# Altium schematic sheet 5_REG2.SchDoc
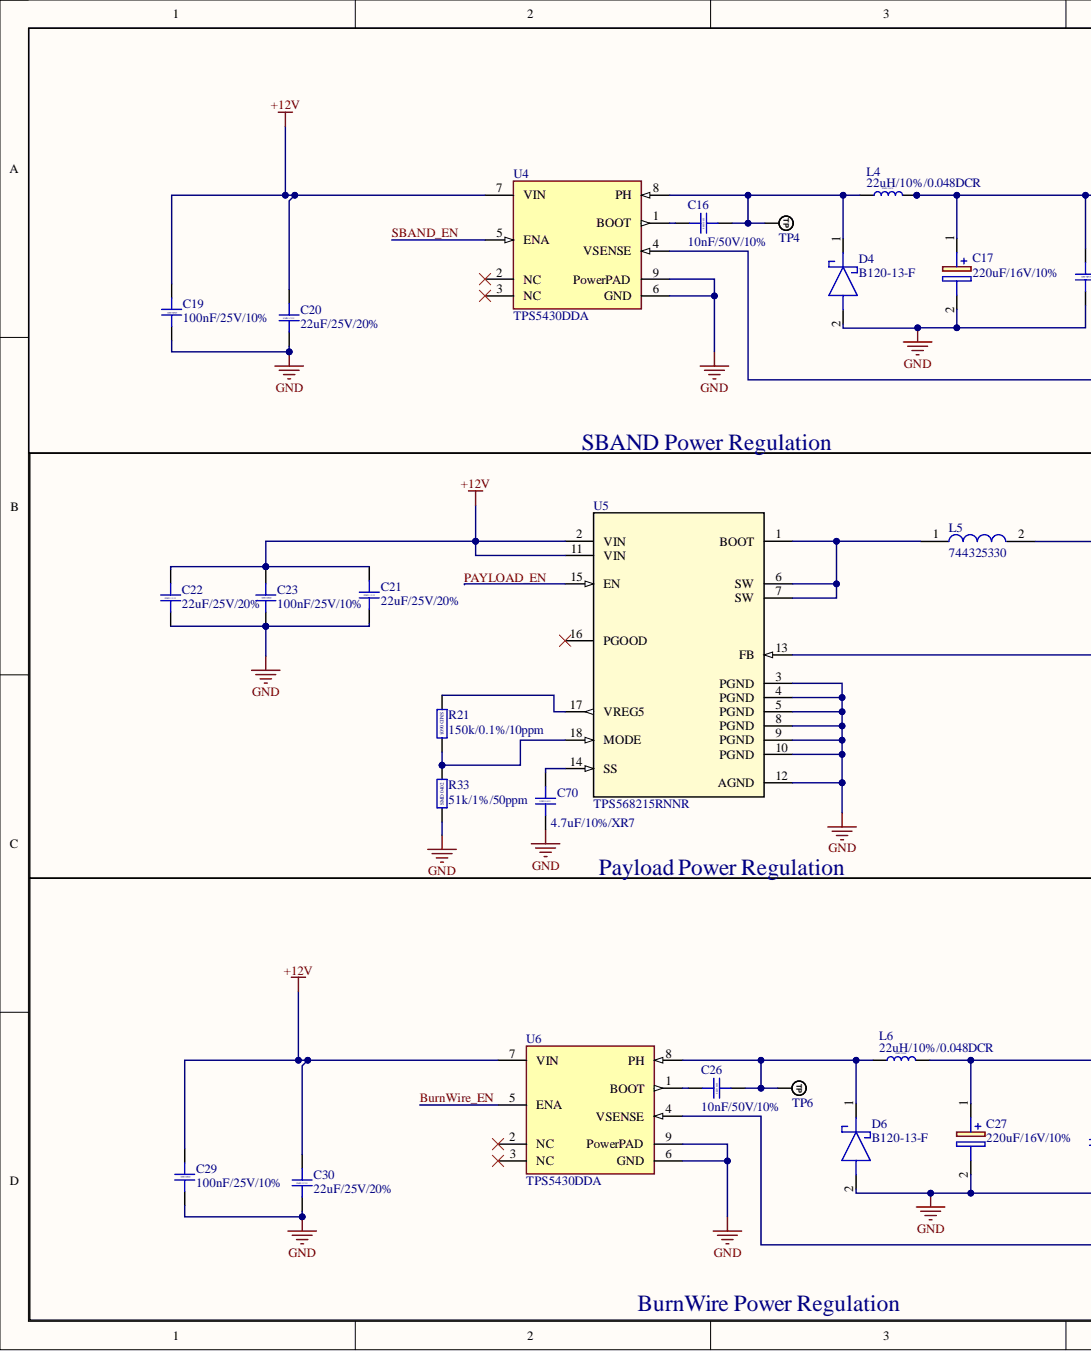
[diagram p0: 5_REG2.SchDoc, left]
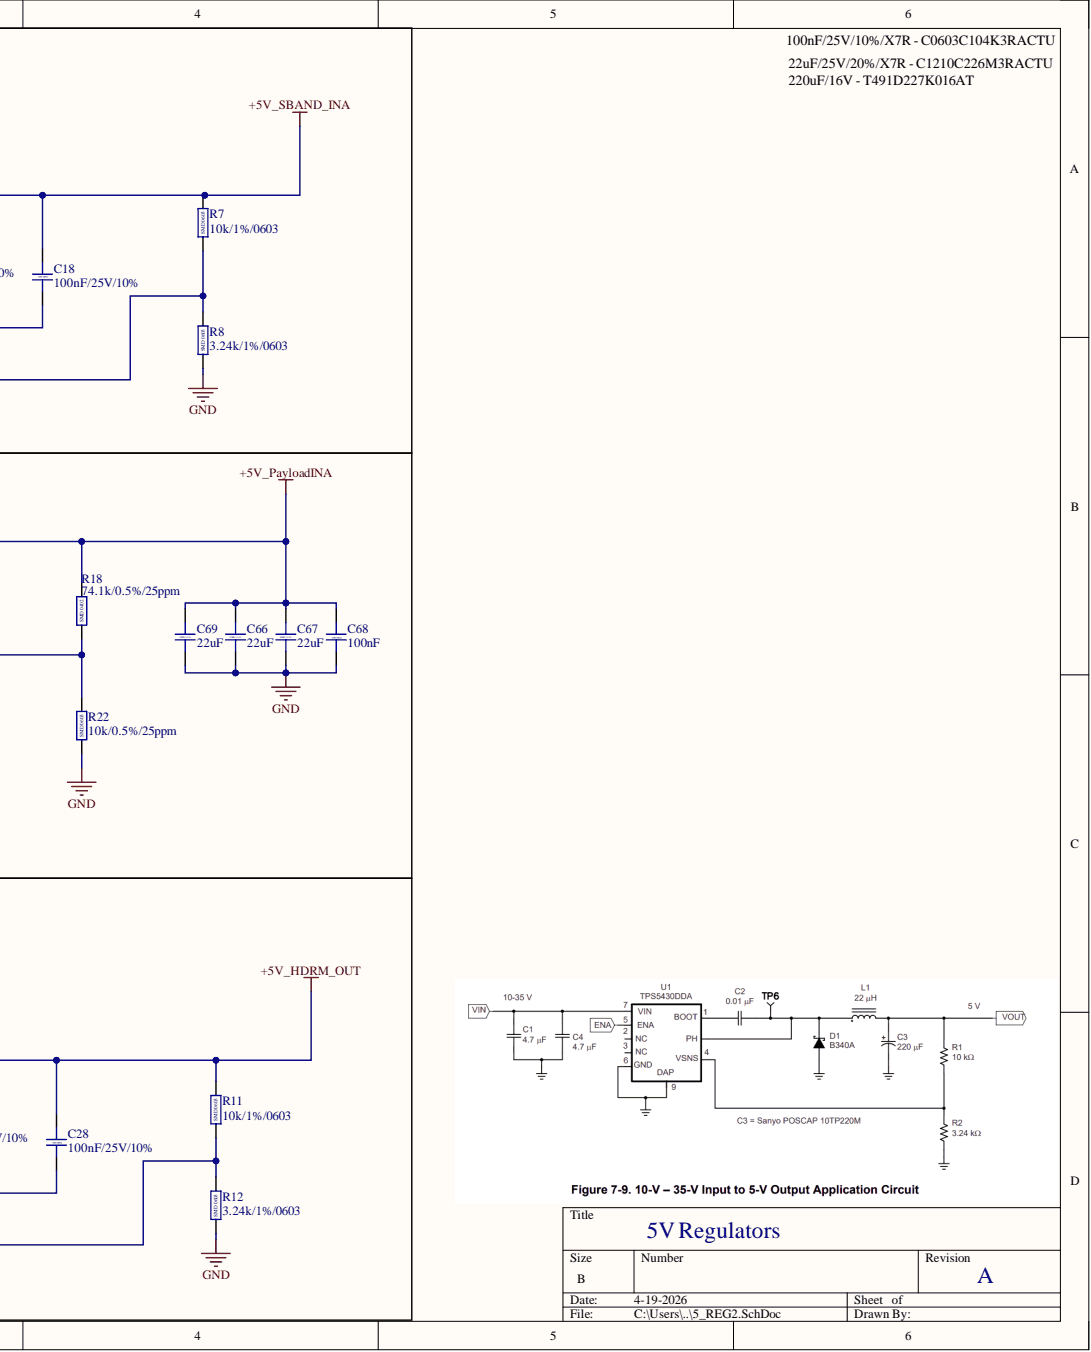
[diagram p1: 5_REG2.SchDoc, right]

## Parts
C16  10nF/50V/10%  [CAP_0402]
C17  220uF/16V/10%  [T491D]
C18  100nF/25V/10%  [CAP_0603]
C19  100nF/25V/10%  [CAP_0603]
C20  22uF/25V/20%  [CAP_1210]
C21  22uF/25V/20%  [CAP_1210]
C22  22uF/25V/20%  [CAP_1210]
C23  100nF/25V/10%  [CAP_0603]
C26  10nF/50V/10%  [CAP_0402]
C27  220uF/16V/10%  [T491D]
C28  100nF/25V/10%  [CAP_0603]
C29  100nF/25V/10%  [CAP_0603]
C30  22uF/25V/20%  [CAP_1210]
C66  22uF  [CAP_1210]
C67  22uF  [CAP_1210]
C68  100nF  [CAP_0603]
C69  22uF  [CAP_1210]
C70  4.7uF/10%/XR7  [CAP_0603]
D4  B120-13-F  [DIOM5226X240N]
D6  B120-13-F  [DIOM5226X240N]
L4  22uH/10%/0.048DCR  [BPSC00131380220M00]
L5  744325330  [WE-HCI_105023]
L6  22uH/10%/0.048DCR  [BPSC00131380220M00]
R7  10k/1%/0603  [RES_0603]
R8  3.24k/1%/0603  [RES_0603]
R11  10k/1%/0603  [RES_0603]
R12  3.24k/1%/0603  [RES_0603]
R18  74.1k/0.5%/25ppm  [RES_0402]
R21  150k/0.1%/10ppm  [RES_0603]
R22  10k/0.5%/25ppm  [RES_0603]
R33  51k/1%/50ppm  [RES_0402]
TP4  TP_NOBOM  [PAD_RND_1MM]  (value not drawn)  (no BOM)
TP6  TP_NOBOM  [PAD_RND_1MM]  (value not drawn)  (no BOM)
U4  TPS5430DDA  [FP-DDA0008J-MFG]
U5  TPS568215RNNR  [RNN0018A]
U6  TPS5430DDA  [FP-DDA0008J-MFG]

## Nets
+5V_HDRM_OUT: C27.1 C28.2 L6.2 R11.2
+5V_PayloadINA: C66.1 C67.1 C68.2 C69.1 L5.2 R18.2
+5V_SBAND_INA: C17.1 C18.2 L4.2
+12V: C19.2 C20.1 C21.1 C22.1 C23.2 C29.2 C30.1 U4.7(VIN) U5.2(VIN) U5.11(VIN) U6.7(VIN)
GND: C17.2 C18.1 C19.1 C20.2 C21.2 C22.2 C23.1 C27.2 C28.1 C29.1 C30.2 C66.2 C67.2 C68.1 C69.2 C70.2 D4.2 D6.2 R8.1 R12.1 R22.1 R33.1 U4.6(GND) U4.9(PowerPAD) U5.3(PGND) U5.4(PGND) U5.5(PGND) U5.8(PGND) U5.9(PGND) U5.10(PGND) U5.12(AGND) U6.6(GND) U6.9(PowerPAD)
BurnWire_EN: U6.5(ENA)
PAYLOAD_EN: U5.15(EN)
SBAND_EN: U4.5(ENA)
NetC16_1: C16.1 U4.1(BOOT)
NetC16_2: C16.2 D4.1 L4.1 TP4.1 U4.8(PH)
NetC26_1: C26.1 U6.1(BOOT)
NetC26_2: C26.2 D6.1 L6.1 TP6.1 U6.8(PH)
NetC70_1: C70.1 U5.14(SS)
NetL5_1: L5.1 U5.1(BOOT) U5.6(SW) U5.7(SW)
NetR7_1: R7.1 R8.2 U4.4(VSENSE)
NetR11_1: R11.1 R12.2 U6.4(VSENSE)
NetR18_1: R18.1 R22.2 U5.13(FB)
NetR21_1: R21.1 U5.17(VREG5)
NetR21_2: R21.2 R33.2 U5.18(MODE)

Not connected: R7 2; U4 2(NC) 3(NC); U5 16(PGOOD); U6 2(NC) 3(NC)

## Library data of the parts
C16 C26 = Capacitor-SMD-0402: Capacitor SMD 0402 RATED; Component Kind: Standard; Library Name: STeRG-Passives-Standard.SchLib
C17 C27 = T491D227K016AT: Manufacturer_Name: YAGEO; Arrow Part Number: T491D227K016AT; Arrow Price/Stock: https://www.arrow.com/en/products/t491d227k016at/kemet-corporation?region=nac; Component Kind: Standard; Datasheet Link: https://content.kemet.com/datasheets/KEM_T2005_T491.pdf; Description: T491D227K016AT; Height: 3.1mm; Manufacturer_Part_Number: T491D227K016AT; Mouser Part Number: 80-T491D227K016AT; Mouser Price/Stock: https://www.mouser.co.uk/ProductDetail/KEMET/T491D227K016AT?qs=wo4x%252BUeoG8UHz8vxfdkfAA%3D%3D
C18 C19 C23 C28 C29 C68 = Capacitor-SMD-0603: C0603C104K3RACTU; Component Kind: Standard; Library Name: STeRG-Passives-Standard.SchLib
C20-C22 C30 C66 C67 C69 = Capacitor-SMD-1210: C1210C226M3RACTU; Component Kind: Standard; Library Name: STeRG-Passives-Standard.SchLib
C70 = Capacitor-SMD-0603: GRM188Z71C475KE21D; Component Kind: Standard; Library Name: STeRG-Passives-Standard.SchLib
D4 D6 = B120-13-F: Schottky Diode; Manufacturer_Name: Diodes Incorporated; Arrow Part Number: B120-13-F; Arrow Price/Stock: https://www.arrow.com/en/products/b120-13-f/diodes-incorporated?region=nac; Component Kind: Standard; Datasheet Link: https://www.diodes.com/assets/Datasheets/ds13002.pdf; Height: 2.4mm; Manufacturer_Part_Number: B120-13-F; Mouser Part Number: 621-B120-F; Mouser Price/Stock: https://www.mouser.co.uk/ProductDetail/Diodes-Incorporated/B120-13-F?qs=m%2FDAVSu2JKQ2m%2FldqYkj8Q%3D%3D; Library Name: STeRG-Passives-Standard.SchLib
L4 L6 = Inductor-SMD-RF-1008: BPSC00131380220M00; Component Kind: Standard; Library Name: STeRG-Passives-Standard.SchLib
L5 = 744325330: Inductor; Manufacturer_Name: Wurth Elektronik; Component Kind: Standard; Datasheet Link: https://www.we-online.com/catalog/datasheet/744325330.pdf; Height: 4.7mm; Manufacturer_Part_Number: 744325330; Mouser Part Number: 710-744325330; Mouser Price/Stock: https://www.mouser.co.uk/ProductDetail/Wurth-Elektronik/744325330?qs=rS3zZhy2AQM4RAdxcGVLNQ%3D%3D
R7 R11 = Resistor-SMD-0603: CRCW060310K0FKEA; Component Kind: Standard; Library Name: STeRG-Passives-Standard.SchLib
R8 R12 = Resistor-SMD-0603: CRCW06033K24FKEA; Component Kind: Standard; Library Name: STeRG-Passives-Standard.SchLib
R18 = Resistor-SMD-0402: RN73H1ETTP7412D25; Component Kind: Standard; Library Name: STeRG-Passives-Standard.SchLib
R21 = Resistor-SMD-0603: RN73C1J150KBTD; Component Kind: Standard; Library Name: STeRG-Passives-Standard.SchLib
R22 = Resistor-SMD-0603: RG1608P-103-D-T5; Component Kind: Standard; Library Name: STeRG-Passives-Standard.SchLib
R33 = Resistor-SMD-0402: MCS04020C5102FE000; Component Kind: Standard; Library Name: STeRG-Passives-Standard.SchLib
TP4 TP6 = TEST_POINT_RND_1MM: Test Point Round 1mm (NO BOM); Library Name: STeRG-Passives-Standard.SchLib
U4 U6 = CMP-2000-04960-2: IC REG BUCK ADJUSTABLE 3A 8SOPWR; Manufacturer: Texas Instruments; Max Duty Cycle: 89%; Max Junction Temp: +125°C; Max Supply Voltage: 36V; Min Supply Voltage: 5.5V; Nominal Supply Current: 3mA; Number Of Outputs: 1; Output Type: AdjustableProgrammable; Output Voltage: 1.23-31V; Standoff Height: 0mm; Switching Frequency: 500kHz; Automotive: No; Category: IC; Component Kind: Standard; ComponentLink2Description: Datasheet; ComponentLink2URL: http://www.ti.com/lit/ds/symlink/tps5431.pdf; Device Class L1: Integrated Circuits (ICs); Device Class L2: Power Management ICs; Device Class L3: Voltage Regulators - Switching; Digikey Description: IC REG BUCK ADJUSTABLE 3A 8SOPWR; Height: 1.7mm; Lead Free: Yes; Library Name: Altium Content Vault; Manufacturer Part Number: TPS5430DDA; Mouser Description: Voltage Regulators - Switching Regulators 5.5 to 36V Input 3A Step Dwn Converter; Octopart: https://octopart.com/tps5430dda-texas+instruments-589977; Output Current: 3A; Package: DDA0008J; RoHS: TRUE; Switching Topology: Buck; Temperature: -40°C to +125°C; Temperature Range High: +125°C; Temperature Range Low: -40°C
U5 = TPS568215RNNR: 4.5-V to 17-V, 8-A synchronous SWIFTâ„¢ buck converter with D-CAP3 control 18-VQFN-HR -40 to 125; Manufacturer_Name: Texas Instruments; Component Kind: Standard; Library Name: TPS568215RNNR.SchLib; Manufacturer_Part_Number: TPS568215RNNR; RefDes: RefDes; TYPE: TYPE; Copyright: Copyright (C) 2026 Ultra Librarian. All rights reserved.
